# Altium schematic sheet lv_FANS.SchDoc(lv_FANS)
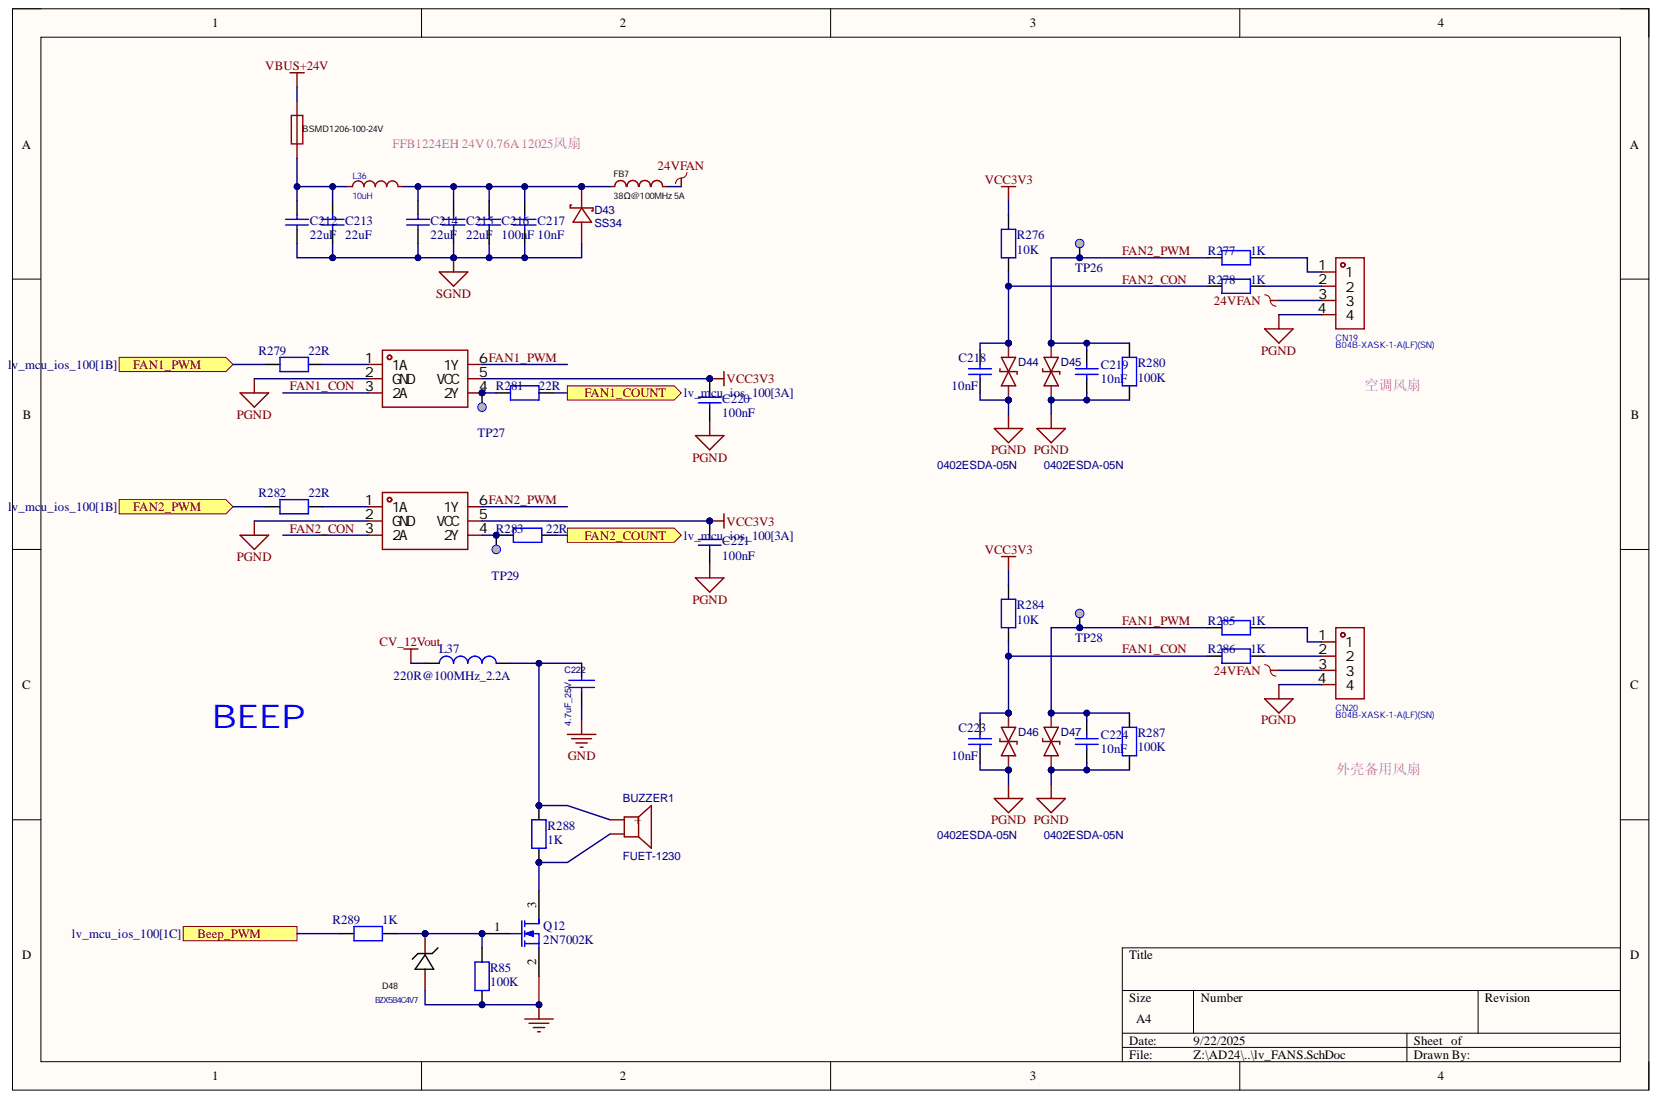
[diagram p0: lv_FANS.SchDoc]

## Parts
BUZZER1  FUET-1230  [BUZ-SMD_L12.0-W12.0-P11.6]
C212  22uF  [C1206]
C213  22uF  [C1206]
C214  22uF  [C1206]
C215  22uF  [C1206]
C216  100nF  [C0402]
C217  10nF  [C0402]
C218  10nF  [C0402]
C219  10nF  [C0402]
C220  100nF  [C0402]
C221  100nF  [C0402]
C222  4.7uF_25V  [C0603]
C223  10nF  [C0402]
C224  10nF  [C0402]
CN19  B04B-XASK-1-A(LF)(SN)  [CONN-TH_B04B-XASK-1-A-LF-SN]
CN20  B04B-XASK-1-A(LF)(SN)  [CONN-TH_B04B-XASK-1-A-LF-SN]
D43  SS34  [DO-214AC_L4.3-W2.7-LS5.3-RD]
D44  0402ESDA-05N  [D0402-BI]
D45  0402ESDA-05N  [D0402-BI]
D46  0402ESDA-05N  [D0402-BI]
D47  0402ESDA-05N  [D0402-BI]
D48  BZX584C4V7  [SOD-523F_L1.2-W0.8-LS1.7-RD]
F8  BSMD1206-100-24V  [1206]
FB7  LCSC Part Name: 38Ω@100MHz 5A  [L1206]  (hidden comment: PZ3216D380-5R0TF)
L36  10uH  [IND-SMD_L3.0-W3.0_FTC303020D]
L37  220R@100MHz_2.2A  [L0603]
Q12  2N7002K  [SOT-23(SOT-23-3)]
R85  100K  [R0402]
R276  10K  [R0603]
R277  1K  [R0603]
R278  1K  [R0603]
R279  22R  [R0402]
R280  100K  [R0603]
R281  22R  [R0402]
R282  22R  [R0402]
R283  22R  [R0402]
R284  10K  [R0603]
R285  1K  [R0603]
R286  1K  [R0603]
R287  100K  [R0603]
R288  1K  [R0603]
R289  1K  [R0402]
TP26  Test Point  [TP_SMD_1MM]  (value not drawn)  (no BOM)
TP27  Test Point  [TP_SMD_1MM]  (value not drawn)  (no BOM)
TP28  Test Point  [TP_SMD_1MM]  (value not drawn)  (no BOM)
TP29  Test Point  [TP_SMD_1MM]  (value not drawn)  (no BOM)
U57  74LVC2G17GW,125  [SOT-363_L2.0-W1.3-P0.65-LS2.1-BR]  (value not drawn)
U58  74LVC2G17GW,125  [SOT-363_L2.0-W1.3-P0.65-LS2.1-BR]  (value not drawn)

## Ports
Beep_PWM, FAN1_COUNT, FAN1_PWM, FAN2_COUNT, FAN2_PWM

## Nets
24VFAN: CN19.3 CN20.3 FB7.1
CV_12Vout: L37.2
GND: C222.2 D48.2 Q12.2 R85.1
PGND: C218.1 C219.1 C220.1 C221.1 C223.1 C224.1 CN19.4 CN20.4 D44.1 D45.1 D46.1 D47.1 R280.1 R287.1 U57.2(GND) U58.2(GND)
SGND: C212.1 C213.1 C214.1 C215.1 C216.1 C217.1 D43.2
VBUS+24V: F8.2
VCC3V3: C220.2 C221.2 R276.2 R284.2 U57.5(VCC) U58.5(VCC)
Beep_PWM: R289.1 ; port Beep_PWM
FAN1_CON: C223.2 D46.2 R284.1 R286.2 U57.3(2A)
FAN1_COUNT: R281.2 ; port FAN1_COUNT
FAN1_PWM: C224.2 D47.2 R285.2 R287.2 TP28.1 U57.6(1Y)
FAN2_CON: C218.2 D44.2 R276.1 R278.2 U58.3(2A)
FAN2_COUNT: R283.1 ; port FAN2_COUNT
FAN2_PWM: C219.2 D45.2 R277.2 R280.2 TP26.1 U58.6(1Y)
NetBUZZER1_1: BUZZER1.1 C222.1 L37.1 R288.2
NetBUZZER1_2: BUZZER1.2 Q12.3 R288.1
NetC212_2: C212.2 C213.2 F8.1 L36.2
NetC214_2: C214.2 C215.2 C216.2 C217.2 D43.1 FB7.2 L36.1
NetCN19_1: CN19.1 R277.1
NetCN19_2: CN19.2 R278.1
NetCN20_1: CN20.1 R285.1
NetCN20_2: CN20.2 R286.1
NetD48_1: D48.1 Q12.1 R85.2 R289.2
NetR279_1: R279.1 ; port FAN1_PWM
NetR279_2: R279.2 U57.1(1A)
NetR281_1: R281.1 TP27.1 U57.4(2Y)
NetR282_1: R282.1 ; port FAN2_PWM
NetR282_2: R282.2 U58.1(1A)
NetR283_2: R283.2 TP29.1 U58.4(2Y)

## Library data of the parts
BUZZER1 = FST-1230: buzzer; BOM_Manufacturer: FUET; Contributor: LCSC; Frequency: 4000Hz; BOM_JLCPCB Part Class: Extended Part; BOM_Manufacturer Part: FST-1230; BOM_Supplier: LCSC; BOM_Supplier Part: C391037; Component Kind: Standard; Library Name: HiPin_Library_AD.IntLib; spicePre: B; spiceSymbolName: FST-1230
C212-C215 = Capacitor_2: 35V, X5R, 10%; Manufacturer: TDK; Manufacturer_Part_Number: C3216X5R1V226MTJ00E; Component Kind: Standard; ComponentLink1Description: Datasheet; ComponentLink1URL: http://tunmcdsvn.tun.st.com/Component_Datasheet_Library/Trunk/Capacitors/Yageo_Multilayer_capacitor_X5R_series_100pF_to_100uF; Library Name: HiPin_Library_AD.IntLib
C216-C221 C223 C224 = Capacitor: 50V, 10%, X7R; Internal_Part_Number: MCD-00003; Manufacturer: muRata; Manufacturer_Part_Number: GRM155R71H104KE14D; Component Kind: Standard; ComponentLink1Description: Datasheet; ComponentLink1URL: http://tunmcdsvn.tun.st.com/Component_Datasheet_Library/Trunk/Capacitors/Yageo_Capacitor_NP0_16V-to-50V; Library Name: HiPin_Library_AD.IntLib; Package: C0402
  C216 C220 C221: Value: 100nF
  C217-C219 C223 C224: Value: 10nF
C222 = CAP NP: X5R, 10%; Manufacturer: muRata; Manufacturer_Part_Number: GRM188R61E475KE11D; Component Kind: Standard
CN19 CN20 = B04B-XASK-1-A(LF)(SN): 插座XA2.5mm,3A,H8.8mm; Manufacturer: JST; Add into BOM: yes; Flame Retardant Rating: UL94V-0; Number of PINs Per Row: 4; Pins Structure: 1x4P; Pitch: 2.5mm; Plastic Material: 玻纤增强PA66; Rated Voltage (Max): 250V; Reference Series: XA; Supplementary Features: 带柱；打K脚; X-Length of Bottom Edge on Board (Spacing Line): 12.5mm; Y-Width of Bottom Edge on Board: 6.4mm; Z-Height of the Board: 8.8mm; Colour: 白色; Component Kind: Standard; Contact Material: 铜合金; Contact Plating: 锡; Convert to PCB: yes; Current Rating (Max): 3A; Datasheet: https://atta.szlcsc.com/upload/public/pdf/source/20200416/C514162_2DCD7D1CD2019D14145B8FEA1B6236C2.pdf; Device: B04B-XASK-1-A(LF)(SN); JLCPCB Part Class: Extended Part; LCSC Part Name: 1x4P 间距:2.5mm 直插 系列:XA; Library Name: HiPin_Library_AD.IntLib; Manufacturer Part: B04B-XASK-1-A(LF)(SN); Mounting Style: 直插; Number of Pins: 4P; Number of Rows: 1; Operating Temperature Range: -25℃~+85℃; PCB3D: CONN-TH_B04B-XASK-1-A-LF-SN; Row Spacing: -; Supplier: LCSC; Supplier Footprint: 插件,P=2.5mm; Supplier Part: C491709; Symbol: B04B-XASK-1-A(LF)(SN)
D43 = SS34_C8678: BOM_Manufacturer: MDD; BOM_JLCPCB Part Class: Basic Part; BOM_Manufacturer Part: SS34; BOM_Supplier: LCSC; BOM_Supplier Part: C8678; Component Kind: Standard; Library Name: HiPin_Library_AD.IntLib; spicePre: D; spiceSymbolName: SS34_C8678
D44-D47 = 0402ESDA-05N: BOM_Manufacturer: Bourne Semicon (Shenzhen); Contributor: LCSC; BOM_JLCPCB Part Class: Extended Part; BOM_Manufacturer Part: 0402ESDA-05N; BOM_Supplier: LCSC; BOM_Supplier Part: C316049; Component Kind: Standard; Library Name: HiPin_Library_AD.IntLib; spicePre: D; spiceSymbolName: 0402ESDA-05N
D48 = BZT52C4V7S: Manufacturer: CJ(江苏长电/长晶); Add into BOM: yes; Diode Configuration: 独立式; Power Dissipation: 500mW; Reverse Leakage Current (Ir): 3uA@4V; Zener Impedance (Zzt): 10Ω; Zener Voltage (Nom): 6.2V; Zener Voltage (Range): 5.8V~6.6V; Component Kind: Standard; Convert to PCB: yes; Datasheet: https://atta.szlcsc.com/upload/public/pdf/source/20211105/0A7AF84D57D732370551C8DD7A10F563.pdf; JLCPCB Part Class: Extended Part; Library Name: HiPin_Library_AD.IntLib; Manufacturer Part: BZX584C4V7; Name: BZX584C4V7; Supplier: LCSC; Supplier Footprint: SOD-123; Tolerance: -
F8 = 2920L260/24DR: 耐压: 24V  最大电流: 40A  保持电流(Ih): 1A  跳闸电流: 1.8A; Manufacturer: HFUSE(佰宏); Component Kind: Standard; Library Name: HiPin_Library_AD.IntLib; Manufacturer Part: BSMD1206-100-24V
FB7 = BLM31PG601SN1L: Manufacturer: Sunlord(顺络); Add into BOM: yes; Circuits: 1; Current Rating: 1.5A; DC Resistance: 80mΩ; Impedance @ Frequency: 600Ω@100MHz; Tolerance: ±25%; Component Kind: Standard; Convert to PCB: yes; Datasheet: https://atta.szlcsc.com/upload/public/pdf/source/20180326/C88997_FE7208E239BA92CF7577D85CF2703D30.pdf; Device: BLM31PG601SN1L; JLCPCB Part Class: Extended Part; Library Name: HiPin_Library_AD.IntLib; Manufacturer Part: PZ3216D380-5R0TF; Supplier: LCSC; Supplier Footprint: 1206; Supplier Part: C16902; Symbol: BLM31PG601SN1L
L36 = FTC303020D100MBCA: Manufacturer: cjiang(长江微电); Add into BOM: yes; DC Resistance (DCR): 135mΩ; Rated Current: 2.5A; Saturation Current (Isat): 3.8A; Tolerance: ±20%; Type: 一体成型电感; Component Kind: Standard; Convert to PCB: yes; Datasheet: https://atta.szlcsc.com/upload/public/pdf/source/20230614/D39208D019DF4F1446B96572E3A14872.pdf; Device: FTC303020D100MBCA; JLCPCB Part Class: Extended Part; LCSC Part Name: 10uH ±20% 3.8A; Library Name: HiPin_Library_AD.IntLib; Manufacturer Part: FTC303020D100MBCA; PCB3D: IND-SMD_L3.0-W3.0_FTC303020D; Supplier: LCSC; Supplier Footprint: SMD,3x3mm; Supplier Part: C7423323; Symbol: FTC303020D100MBCA; Value: 10uH
L37 = MCD-00047: SMD Chip ferrite bead, 220R@100MHz +/-25%,2.2A, 50mohm; Manufacturer: Sunlord; Manufacturer_Part_Number: UPZ1608U221-2R2TF; Database Table Name: MCD_PARTS$; Component Kind: Standard; ComponentLink1Description: Datasheet; ComponentLink1URL: http://tunmcdsvn.tun.st.com/Component_Datasheet_Library/Trunk/Inductors/TaiTech_Bead_FCM1608_series; Internal_Part_Number: MCD-00047; Library Name: MCD_PARTS_database.DbLib
Q12 = MOSFET-N: N沟道,60V,0.115A,7Ω@10V; Manufactu: CJ江苏长电; ManufacturerNumber: 2N7002; Component Kind: Standard; Library Name: HiPin_Library_AD.IntLib; Package: SOT-23; SuppliersPartNumber: C8545
R85 R277-R283 R285-R287 R289 = RES: 1%; Value: 10K; Component Kind: Standard; Library Name: HiPin_Library_AD.IntLib
R276 R284 = RES: 1%; Component Kind: Standard; Library Name: HiPin_Library_AD.IntLib; Value: 10K
R288 = RES: Resistor; Component Kind: Standard; Library Name: HiPin_Library_AD.IntLib
TP26-TP29 = MCD-00074: Test Point 1mm; Part_Type: Mechanical; Database Table Name: MCD_PARTS$; ComponentLink1Description: Datasheet; ComponentLink1URL: http://na; ECCN_EU: n/a; ECCN_US: n/a; Internal_Part_Number: MCD-00074; Library Name: MCD_PARTS_database.DbLib; Manufacturer: n/a; Manufacturer_Part_Number: n/a; Provided_By: n/a; Status: Released
U57 U58 = 74LVC2G17GW,125: 2路斯密特触发器; Manufacturer: Nexperia(安世); Add into BOM: yes; Current - Output High(IOH): 32mA; Input type: 施密特触发器; Logic Family: 74LVC; Number of Bits per Element: 1; Number of Elements: 2; output type: 推挽; Voltage - Supply: 1.65V~5.5V; Component Kind: Standard; Convert to PCB: yes; Current - Output Low(IOL): 32mA; Datasheet: https://atta.szlcsc.com/upload/public/pdf/source/20240724/F8BC06F6786231A20F016105A2EDA3EF.pdf; Device: 74LVC2G17GW,125; JLCPCB Part Class: Extended Part; LCSC Part Name: 74LVC2G17GW,125; Library Name: HiPin_Library_AD.IntLib; Manufacturer Part: 74LVC2G17GW,125; Operating Temperature: -40℃~+125℃; PCB3D: SOT-363-6P_L2.0-W1.3-H1.1-LS2.0-P0.65; Supplier: LCSC; Supplier Footprint: SOT-363; Supplier Part: C80500; Symbol: 74LVC2G17GW,125
